# Altium schematic sheet Voltage Regulator.SchDoc
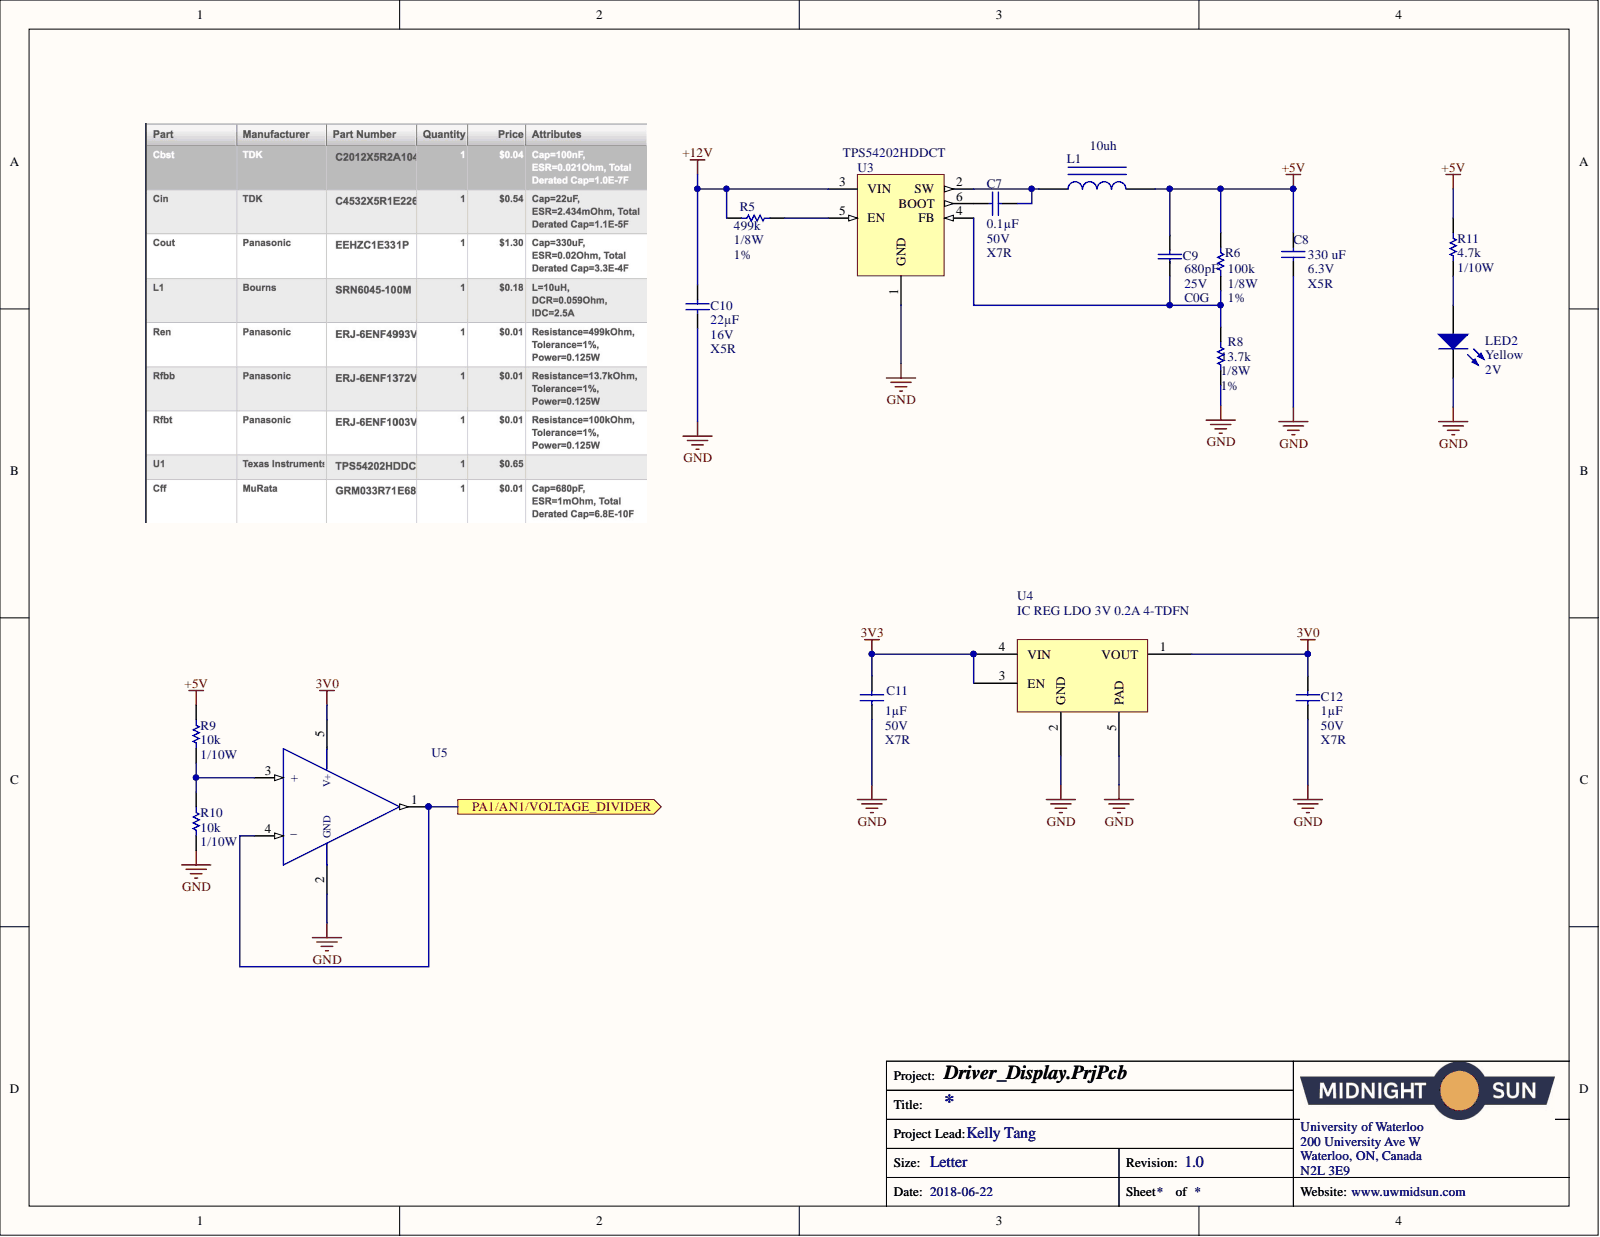
[diagram p0: Voltage Regulator.SchDoc]

## Parts
C7  Capacitance: 0.1µF  [CAP, 0603]  (hidden comment: CAP CER 0.1UF 50V 10% X7R 0603)
C8  Temperature Coefficient: X5R  Voltage: 6.3V  [CAP, 1210]  (hidden comment: CAP CER 330UF 6.3V X5R 1210)
C9  Capacitance: 680pF  Voltage Rating (DC): 25V  [CAP, 0603]  (hidden comment: CAP CER 680PF 25V C0G 0201)
C10  Capacitance: 22µF  Temperature Coefficient: X5R  Voltage: 16V  [CAP, 1210]  (hidden comment: CAP CER 22UF 16V ±20% X5R 1210)
C11  Capacitance: 1µF  Temperature Coefficient: X7R  Voltage: 50V  [CAP, 0603]  (hidden comment: CAP CER 1UF 50V 10% X7R 0603)
C12  Capacitance: 1µF  Temperature Coefficient: X7R  Voltage: 50V  [CAP, 0603]  (hidden comment: CAP CER 1UF 50V 10% X7R 0603)
L1  Inductance: 10uh  [INDP100102X31N]  (hidden comment: IND 10UH 2.8A 59 MOHM SMD)
LED2  Color: Yellow  Voltage - Forward (Vf) (Typ): 2V  [LED_0603]  (hidden comment: LED YELLOW CLEAR 2.1V 0603)
R5  Max Power Dissipation: 1/8W  Resistance: 499k  [RES 1206]  (hidden comment: RES 499K OHM 1% 1/4W 1206)
R6  Power Rating: 1/8W  Resistance: 100k  Tolerance: 1%  [RES 1206]  (hidden comment: ES 100K OHM 1% 1/4W 1206)
R8  Power Rating: 1/8W  Resistance: 13.7k  Tolerance: 1%  [RES 1206]  (hidden comment: RES 13.7K OHM 1% 1/4W 1206)
R9  Power: 1/10W  Resistance: 10k  [RES, 0603]  (hidden comment: RES 10K OHM 1% 1/10W 0603)
R10  Power: 1/10W  Resistance: 10k  [RES, 0603]  (hidden comment: RES 10K OHM 1% 1/10W 0603)
R11  Power (Watts): 1/10W  Resistance (Ohms): 4.7k  [RES, 0603]  (hidden comment: RES 4.7K OHM 1% 1/10W 0603)
U3  Manufacturer Part Number: TPS54202HDDCT  [SOT23-6]  (hidden comment: IC REG BUCK ADJ 2A SYNC 6SOT)
U4  IC REG LDO 3V 0.2A 4-TDFN  Voltage - Output: 3V  [IC REG LDO 3V 0.2A 4-TDFN]
U5  IC OP AMP GEN PURPOSE RR 10MHZ SOT-23-5  [IC OP AMP GP RR 10MHZ SOT-23-5]  (value not drawn)

## Ports
PA1/AN1/VOLTAGE_DIVIDER

## Nets
3V0: C12.1 U4.1(VOUT) U5.5
3V3: C11.1 U4.3(EN) U4.4(VIN)
+5V: C8.1 C9.1 L1.2 R6.1 R9.1 R11.1
+12V: C10.1 R5.2 U3.3(VIN)
GND: C8.2 C10.2 C11.2 C12.2 LED2.2 R8.2 R10.2 U3.1(GND) U4.2(GND) U4.5(PAD) U5.2
PA1/AN1/VOLTAGE_DIVIDER: U5.1 U5.4 ; port PA1/AN1/VOLTAGE_DIVIDER
NetC7_1: C7.1 U3.6(BOOT)
NetC7_2: C7.2 L1.1 U3.2(SW)
NetC9_2: C9.2 R6.2 R8.1 U3.4(FB)
NetLED2_1: LED2.1 R11.2
NetR5_1: R5.1 U3.5(EN)
NetR9_2: R9.2 R10.1 U5.3(+)

## Library data of the parts
C7 = CAP CER 0.1UF 50V 10% X7R 0603: 0.10µF ±10% 50V Ceramic Capacitor X7R 0603 (1608 Metric); Manufacturer 1: AVX Corporation; Manufacturer Part Number 1: 06035C104KAT2A; Component Kind: Standard; ComponentLink1Description: http://datasheets.avx.com/X7RDielectric.pdf; ComponentLink1URL: http://datasheets.avx.com/X7RDielectric.pdf; Height - Seated (Max): -; Lead Spacing: -; Lead Style: -; Mounting Type: Surface Mount, MLCC; Operating Temperature: -55°C ~ 125°C; Package / Case: 0603 (1608 Metric); Packaging: Cut Tape (CT); Part Status: Active; Ratings: -; Size / Dimension: 0.063" L x 0.032" W (1.60mm x 0.81mm); Supplier 1: Digi-Key; Supplier Part Number 1: 478-5052-1-ND; Temperature Coefficient: X7R; Thickness (Max): 0.035" (0.90mm); Tolerance: ±10%; Voltage: 50V; Library Name: Schematic Diagrams.SchLib
C8 = CAP CER 330UF 6.3V X5R 1210: 330µF ±20% 6.3V Ceramic Capacitor X5R 1210 (3225 Metric); Manufacturer: Taiyo Yuden; Manufacturer Part Number: JMK325ABJ337MM-P; Capacitance: 330 uF; Component Kind: Standard; ComponentLink1Description: http://ds.yuden.co.jp/TYCOMPAS/ut/detail.do?productNo=JMK325ABJ337MM-P&fileName=JMK325ABJ337MM-P_SS&mode=specSheetDownload; ComponentLink1URL: http://ds.yuden.co.jp/TYCOMPAS/ut/detail.do?productNo=JMK325ABJ337MM-P&fileName=JMK325ABJ337MM-P_SS&mode=specSheetDownload; Pricing 1: 1=5.57, 10=4.492, 100=3.5039, 500=2.60546 (USD); Stock 1: 334; Supplier 1: Digi-Key; Supplier Part Number 1: 587-5449-1-ND; Library Name: Schematic Diagrams.SchLib
C9 = CAP CER 680PF 25V C0G 0201: 680pF ±5% 25V Ceramic Capacitor C0G, NP0 0201 (0603 Metric); Manufacturer: Murata; Manufacturer Part Number: GRM0335C1E681JA01D; Component Kind: Standard; Dielectric: C0G; Length: 600um; Pricing 1: 1=0.1, 10=0.017, 100=0.0078, 500=0.0056, 1000=0.0044, 2500=0.004, 5000=0.0037 (USD); Stock 1: 66100; Supplier 1: Digi-Key; Supplier Part Number 1: 490-14330-1-ND; Thickness: 300um; Tolerance: 5%; Width: 300um; Library Name: Schematic Diagrams.SchLib
C10 = CAP CER 22UF 16V ±20% X5R 1210: 22µF ±20% 16V Ceramic Capacitor X5R 1210 (3225 Metric); Manufacturer 1: Murata Electronics North America; Manufacturer Part Number 1: GRM32ER61C226ME20L; Component Kind: Standard; ComponentLink1Description: http://www.murata.com/~/media/webrenewal/support/library/catalog/products/capacitor/mlcc/c02e.ashx; ComponentLink1URL: http://www.murata.com/~/media/webrenewal/support/library/catalog/products/capacitor/mlcc/c02e.ashx; Height - Seated (Max): -; Lead Spacing: -; Lead Style: -; Mounting Type: Surface Mount, MLCC; Operating Temperature: -55°C ~ 85°C; Package / Case: 1210 (3225 Metric); Packaging: Cut Tape (CT); Part Status: Active; Ratings: -; Size / Dimension: 0.126" L x 0.098" W (3.20mm x 2.50mm); Supplier 1: Digi-Key; Supplier Part Number 1: 490-1881-1-ND; Thickness (Max): 0.106" (2.70mm); Tolerance: ±20%; Library Name: Schematic Diagrams.SchLib
C11 C12 = CAP CER 1UF 50V 10% X7R 0603: 1µF ±10% 50V Ceramic Capacitor X7R 0603 (1608 Metric); Manufacturer 1: Taiyo Yuden; Manufacturer Part Number 1: UMK107AB7105KA-T; Component Kind: Standard; ComponentLink1Description: http://www.yuden.co.jp/productdata/catalog/en/mlcc_all_e.pdf; ComponentLink1URL: http://www.yuden.co.jp/productdata/catalog/en/mlcc_all_e.pdf; Height - Seated (Max): -; Lead Spacing: -; Lead Style: -; Mounting Type: Surface Mount, MLCC; Operating Temperature: -55°C ~ 125°C; Package / Case: 0603 (1608 Metric); Packaging: Cut Tape (CT); Part Status: Active; Ratings: -; Size / Dimension: 0.063" L x 0.031" W (1.60mm x 0.80mm); Supplier 1: Digi-Key; Supplier Part Number 1: 587-3247-1-ND; Thickness (Max): 0.037" (0.95mm); Tolerance: ±10%; Library Name: Schematic Diagrams.SchLib
L1 = IND 10UH 2.8A 59 MOHM SMD: 10µH Shielded Inductor 2.8A 59 mOhm Max Nonstandard; Manufacturer: Sumida; Manufacturer Part Number: CDRH103RNP-100NC-B; Core Material: Ferrite; DC Resistance (DCR): 59mR; Saturation Current: 3.18A; Test Frequency: 100kHz; Tolerance: 30%; Case/Package: Nonstandard; Component Kind: Standard; ComponentLink1Description: http://www.mouser.com/ds/2/390/CDRH103R-523372.pdf; ComponentLink1URL: http://www.mouser.com/ds/2/390/CDRH103R-523372.pdf; ComponentLink2Description: http://www.sumida.com/products/pdf/CDRH103R.pdf; ComponentLink2URL: http://www.sumida.com/products/pdf/CDRH103R.pdf; ComponentLink3Description: http://products.sumida.com/products/pdf/CDRH103R.pdf; ComponentLink3URL: http://products.sumida.com/products/pdf/CDRH103R.pdf; Current Rating: 2.8A; Depth: 10.3mm; Height: 3.1mm; Height - Seated (Max): 0.122inch; Lead Free: Lead Free; Length: 0.402inch; Max DC Current: 2.8A; Max Operating Temperature: 100°C; Min Operating Temperature: -40°C; Mount: Surface Mount; Package Quantity: 1000; Packaging: Tape and Reel; Pricing 1: 1=1.53, 10=1.42, 100=1.027, 500=0.7866 (USD); Radiation Hardening: No; Shielding: Shielded; Stock 1: 69; Supplier 1: Digi-Key; Supplier Part Number 1: 308-1910-1-ND; Termination: SMD/SMT; Width: 0.394inch; Library Name: Schematic Diagrams.SchLib
LED2 = LED YELLOW CLEAR 2.1V 0603: Yellow 590nm LED Indication - Discrete 2V 0603 (1608 Metric); Manufacturer: Wurth Electronics Inc.; Manufacturer Part Number: 150060YS75000; Current - Test: 20mA; Lens Color: Colorless; Lens Style/Size: Rectangle with Flat Top, 1.10mm x 0.70mm; Millicandela Rating: 120mcd; Viewing Angle: 140°; Wavelength - Peak: 595nm; Category: Optoelectronics; Component Kind: Standard; ComponentLink1Description: http://katalog.we-online.de/led/datasheet/150060YS75000.pdf; ComponentLink1URL: http://katalog.we-online.de/led/datasheet/150060YS75000.pdf; Features: -; Height (Max): 0.80mm; Lens Transparency: Clear; Mounting Type: Surface Mount; Package / Case: 0603 (1608 Metric); Packaging: Cut Tape (CT); Part Status: Active; Pricing 1: 1=0.21, 100=0.1617, 500=0.13964 (CAD); RoHS: RoHS Compliant; Size / Dimension: 1.60mm L x 0.80mm W; Stock 1: 10523; Supplier 1: Digi-Key; Supplier Device Package: 0603; Supplier Part Number 1: 732-4981-1-ND; Wavelength - Dominant: 590nm; Library Name: Schematic Diagrams.SchLib
R5 = RES 499K OHM 1% 1/4W 1206: Manufacturer: Stackpole Electronics; Manufacturer Part Number: RMCF1206FT499K; Temperature Coefficient: 100ppm/°C; Case/Package: 1206; Case Code (Imperial): 1206; Case Code (Metric): 3216; Component Kind: Standard; ComponentLink1Description: https://www.seielect.com/Catalog/SEI-RMCF_RMCP.pdf; ComponentLink1URL: https://www.seielect.com/Catalog/SEI-RMCF_RMCP.pdf; ComponentLink2Description: http://components.arrow.com/part/dataview/50498553S9833735N1174; ComponentLink2URL: http://components.arrow.com/part/dataview/50498553S9833735N1174; Composition: Thick Film; Contact Plating: Tin,Matte; Height: 0.028inch; Length: 0.126inch; Max Operating Temperature: 155°C; Min Operating Temperature: -55°C; Mount: Surface Mount; Number of Terminations: 2; Packaging: Tape and Reel; Pricing 1: 1=0.1, 10=0.035, 100=0.0141, 1000=0.00635, 2500=0.00552 (USD); Radiation Hardening: No; Stock 1: 60246; Supplier 1: Digi-Key; Supplier Part Number 1: RMCF1206FT499KCT-ND; Tolerance: 1%; Width: 0.063inch; Library Name: Schematic Diagrams.SchLib
R6 = RES 100K OHM 1% 1/4W 1206: 100 kOhms ±1% 0.25W, 1/4W Chip Resistor 1206 (3216 Metric) Moisture Resistant Thick Film; Manufacturer: Yageo; Manufacturer Part Number: RC1206FR-07100KL; Temperature Coefficient: 100ppm/°C; Voltage Rating: 200V; Case/Package: 1206; Case Code (Imperial): 1206; Case Code (Metric): 3216; Component Kind: Standard; ComponentLink1Description: https://datasheet.ciiva.com/7857/444357-7857239.pdf; ComponentLink1URL: https://datasheet.ciiva.com/7857/444357-7857239.pdf; ComponentLink2Description: https://datasheet.ciiva.com/7857/444357-7857240.pdf; ComponentLink2URL: https://datasheet.ciiva.com/7857/444357-7857240.pdf; ComponentLink3Description: https://datasheet.ciiva.com/16997/pyu-rc1206-51-rohs-l-4-16997053.pdf; ComponentLink3URL: https://datasheet.ciiva.com/16997/pyu-rc1206-51-rohs-l-4-16997053.pdf; Composition: Thick Film; Depth: 1.6mm; Height: 0.55mm; Lead Free: Lead Free; Length: 3.1mm; Max Operating Temperature: 155°C; Min Operating Temperature: -55°C; Packaging: Tape and Reel; Pricing 1: 1=0.1, 10=0.033, 100=0.0136, 1000=0.0061, 2500=0.00529 (USD); REACH SVHC: No SVHC; Stock 1: 1498131; Supplier 1: Digi-Key; Supplier Part Number 1: 311-100KFRCT-ND; Termination: SMD/SMT; Library Name: Schematic Diagrams.SchLib
R8 = RES 13.7K OHM 1% 1/4W 1206: 13.7 kOhms ±1% 0.25W, 1/4W Chip Resistor 1206 (3216 Metric) Moisture Resistant Thick Film; Manufacturer: Yageo; Manufacturer Part Number: RC1206FR-0713K7L; Temperature Coefficient: 100ppm/°C; Voltage Rating: 200V; Case/Package: 1206; Case Code (Imperial): 1206; Case Code (Metric): 3216; Component Kind: Standard; ComponentLink1Description: http://components.arrow.com/part/dataview/0323_03537901; ComponentLink1URL: http://components.arrow.com/part/dataview/0323_03537901; ComponentLink2Description: http://www.yageo.com/documents/recent/PYu-RC_Group_51_RoHS_L_2.pdf; ComponentLink2URL: http://www.yageo.com/documents/recent/PYu-RC_Group_51_RoHS_L_2.pdf; ComponentLink3Description: http://www.yageo.com/documents/recent/PYu-RC1206_51_RoHS_L-4.pdf; ComponentLink3URL: http://www.yageo.com/documents/recent/PYu-RC1206_51_RoHS_L-4.pdf; ComponentLink4Description: http://www.yageo.com.tw/exep/pages/download/literatures/PYu-R_INT-thick_7.pdf; ComponentLink4URL: http://www.yageo.com.tw/exep/pages/download/literatures/PYu-R_INT-thick_7.pdf; ComponentLink5Description: http://www.mouser.com/ds/2/447/PYu-RC1206_51_RoHS_L-4-349080.pdf; ComponentLink5URL: http://www.mouser.com/ds/2/447/PYu-RC1206_51_RoHS_L-4-349080.pdf; ComponentLink6Description: http://www.yageo.com/documents/recent/PYu-RC1206_51_RoHS_L_5.pdf; ComponentLink6URL: http://www.yageo.com/documents/recent/PYu-RC1206_51_RoHS_L_5.pdf; Composition: Thick Film; Contact Plating: Tin,Matte; Depth: 1.6mm; Height: 0.55mm; Lead Free: Lead Free; Length: 3.1mm; Max Operating Temperature: 155°C; Military Standard: Not; Min Operating Temperature: -55°C; Packaging: Tape and Reel; Pricing 1: 1=0.1, 10=0.033, 100=0.0136, 1000=0.0061, 2500=0.00529 (USD); Radiation Hardening: No; Stock 1: 44928; Supplier 1: Digi-Key; Supplier Part Number 1: 311-13.7KFRCT-ND; Termination: SMD/SMT; Voltage Rating (DC): 200V; Width: 1.6mm; Library Name: Schematic Diagrams.SchLib
R9 R10 = RES 10K OHM 1% 1/10W 0603: RES SMD 10K OHM 1% 1/10W 0603; Manufacturer 1: Yageo; Manufacturer Part Number 1: RC0603FR-0710KL; Temperature Coefficient: ±100ppm/°C; Component Kind: Standard; ComponentLink1Description: http://www.yageo.com/documents/recent/PYu-RC_Group_51_RoHS_L_7.pdf; ComponentLink1URL: http://www.yageo.com/documents/recent/PYu-RC_Group_51_RoHS_L_7.pdf; Composition: Thick Film; Failure Rate: -; Height - Seated (Max): 0.022" (0.55mm); Operating Temperature: -55°C ~ 155°C; Package / Case: 0603 (1608 Metric); Packaging: Cut Tape (CT); Part Status: Active; Size / Dimension: 0.063" L x 0.031" W (1.60mm x 0.80mm); Supplier 1: Digi-Key; Supplier Device Package: 0603; Supplier Part Number 1: 311-10.0KHRCT-ND; Tolerance: ±1%; Library Name: Schematic Diagrams.SchLib
R11 = RES 4.7K OHM 1% 1/10W 0603: 4.7k Ohm ±1% 0.1W, 1/10W Chip Resistor 0603 (1608 Metric) Moisture Resistant Thick Film; Manufacturer 1: Yageo; Manufacturer Part Number 1: RC0603FR-074K7L; Description 1: RES SMD 4.7K OHM 1% 1/10W 0603; Temperature Coefficient: ±100ppm/°C; Category: Resistors; Component Kind: Standard; ComponentLink1Description: http://www.yageo.com/documents/recent/PYu-RC_Group_51_RoHS_L_7.pdf; ComponentLink1URL: http://www.yageo.com/documents/recent/PYu-RC_Group_51_RoHS_L_7.pdf; Composition: Thick Film; Failure Rate: -; Features: Moisture Resistant; Height - Seated (Max): 0.022" (0.55mm); Operating Temperature: -55°C ~ 155°C; Package / Case: 0603 (1608 Metric); Packaging: Cut Tape (CT); Part Status: Active; Pricing 1: 1=0.16, 10=0.022, 100=0.0089, 1000=0.00402, 2500=0.00349 (CAD); RoHS: RoHS Compliant; Size / Dimension: 0.063" L x 0.031" W (1.60mm x 0.80mm); Stock 1: 3487566; Supplier 1: Digi-Key; Supplier Device Package: 0603; Supplier Part Number 1: 311-4.70KHRCT-ND; Tolerance: ±1%; Library Name: Schematic Diagrams.SchLib
U3 = IC REG BUCK ADJ 2A SYNC 6SOT: Buck Switching Regulator IC Positive Adjustable 0.596V 1 Output 2A SOT-23-6 Thin, TSOT-23-6; Manufacturer: Texas Instruments; Max Input Voltage: 28V; Max Output Voltage: 26V; Min Input Voltage: 4.5V; Min Output Voltage: 600mV; Number of Outputs: 1; Quiescent Current: 40uA; Switching Frequency: 500kHz; Case/Package: SOT-6; Component Kind: Standard; ComponentLink1Description: http://www.ti.com/lit/ds/symlink/tps54202h.pdf; ComponentLink1URL: http://www.ti.com/lit/ds/symlink/tps54202h.pdf; ComponentLink2Description: http://www.ti.com/general/docs/lit/getliterature.tsp?genericPartNumber=TPS54202H&amp;&amp;fileType=pdf; ComponentLink2URL: http://www.ti.com/general/docs/lit/getliterature.tsp?genericPartNumber=TPS54202H&amp;&amp;fileType=pdf; ComponentLink3Description: http://www.ti.com/general/docs/lit/getliterature.tsp?genericPartNumber=TPS54202H&&fileType=pdf; ComponentLink3URL: http://www.ti.com/general/docs/lit/getliterature.tsp?genericPartNumber=TPS54202H&&fileType=pdf; Lead Free: Lead Free; Max Operating Temperature: 125°C; Min Operating Temperature: -40°C; Number of Pins: 6; Output Current: 2A; Output Voltage: 26V; Packaging: Cut Tape; Pricing 1: 1=2, 10=1.796, 100=1.4436 (USD); REACH SVHC: No SVHC; Stock 1: 2574; Supplier 1: Digi-Key; Supplier Part Number 1: 296-44497-1-ND; Library Name: Schematic Diagrams.SchLib
U4 = IC REG LDO 3V 0.2A 4-TDFN: Manufacturer: Microchip Technology; Manufacturer Part Number: MIC94310-PYMT-TR; Current - Limit (Min): 250mA; Current - Output: 200mA; Regulator Topology: Positive Fixed; Voltage - Dropout (Typical): 0.04V @ 200mA; Voltage - Input: Up to 3.6V; Category: Integrated Circuits (ICs); Component Kind: Standard; ComponentLink1Description: http://www.microchip.com/mymicrochip/filehandler.aspx?ddocname=en580409; ComponentLink1URL: http://www.microchip.com/mymicrochip/filehandler.aspx?ddocname=en580409; Mounting Type: Surface Mount; Operating Temperature: -40°C ~ 125°C; Package / Case: 4-UFDFN Exposed Pad; Packaging: Cut Tape (CT); Part Status: Active; Pricing 1: 1=0.45, 25=0.3708, 100=0.3386 (CAD); RoHS: RoHS Compliant; Stock 1: 4802; Supplier 1: Digi-Key; Supplier Device Package: 4-TDFN (1.2x1.6); Supplier Part Number 1: 576-4761-1-ND; Library Name: Schematic Diagrams.SchLib
U5 = IC OP AMP GEN PURPOSE RR 10MHZ SOT-23-5: General Purpose Amplifier 1 Circuit Rail-to-Rail SOT-23-5; Manufacturer 1: Texas Instruments; Manufacturer Part Number 1: TLV316QDBVRQ1; Current - Output / Channel: 50mA; Current - Supply: 400µA; Description 1: IC OP AMP GP RR 10MHZ 5-SOT-23; Number of Circuits: 1; Slew Rate: 6 V/µs; Voltage - Supply, Single/Dual (±): 1.8 V ~ 5.5 V, ±0.9 V ~ 2.75 V; -3db Bandwidth: -; Amplifier Type: General Purpose; Category: Integrated Circuits (ICs); Component Kind: Standard; ComponentLink1Description: http://www.ti.com/lit/ds/symlink/tlv316-q1.pdf; ComponentLink1URL: http://www.ti.com/lit/ds/symlink/tlv316-q1.pdf; Mounting Type: Surface Mount; Operating Temperature: -40°C ~ 125°C; Output Type: Rail-to-Rail; Package / Case: SC-74A, SOT-753; Packaging: Cut Tape (CT); Part Status: Active; Pricing 1: 1=1.33, 10=1.185, 100=0.9234, 500=0.76276, 1000=0.60218 (CAD); RoHS: RoHS Compliant; Stock 1: 1747; Supplier 1: Digi-Key; Supplier Device Package: SOT-23-5; Supplier Part Number 1: 296-45323-1-ND; Library Name: Schematic Diagrams.SchLib
